# Altium schematic sheet Led_Matric_and_Driver.SchDoc(Led_Matric_and_Driver)
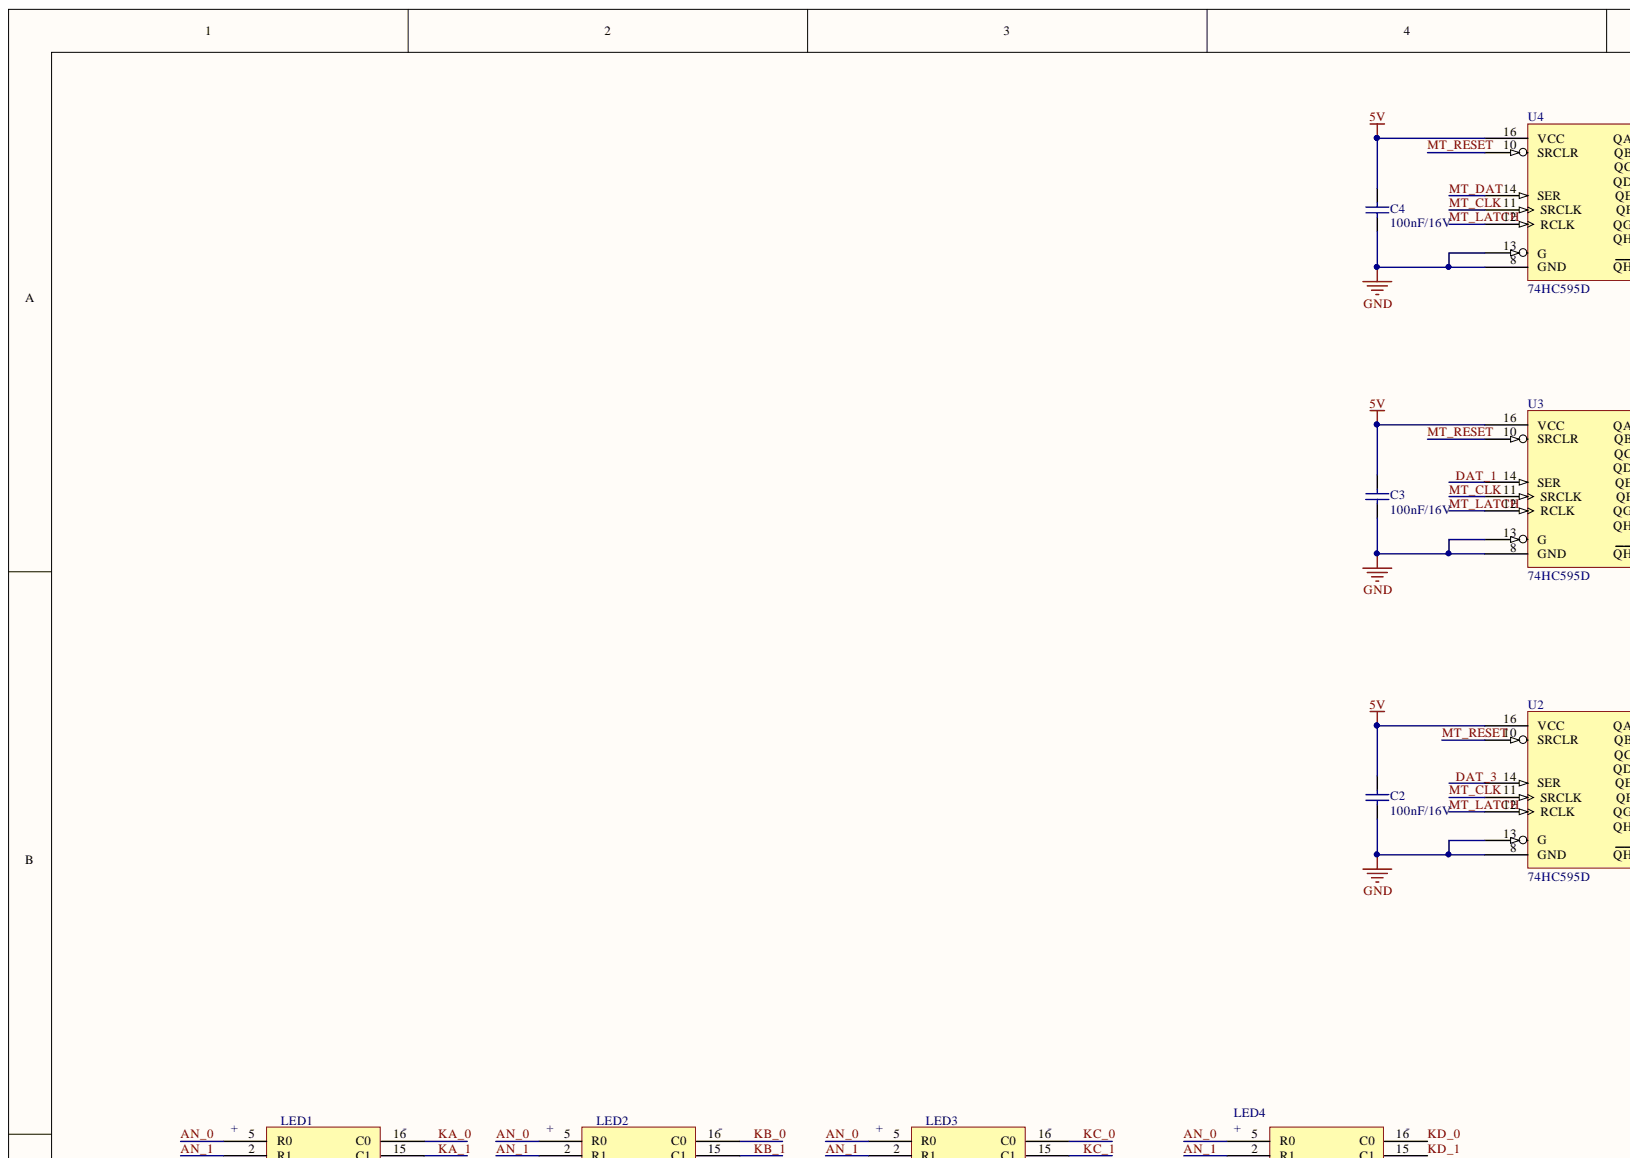
[diagram p0: Led_Matric_and_Driver.SchDoc, top left]
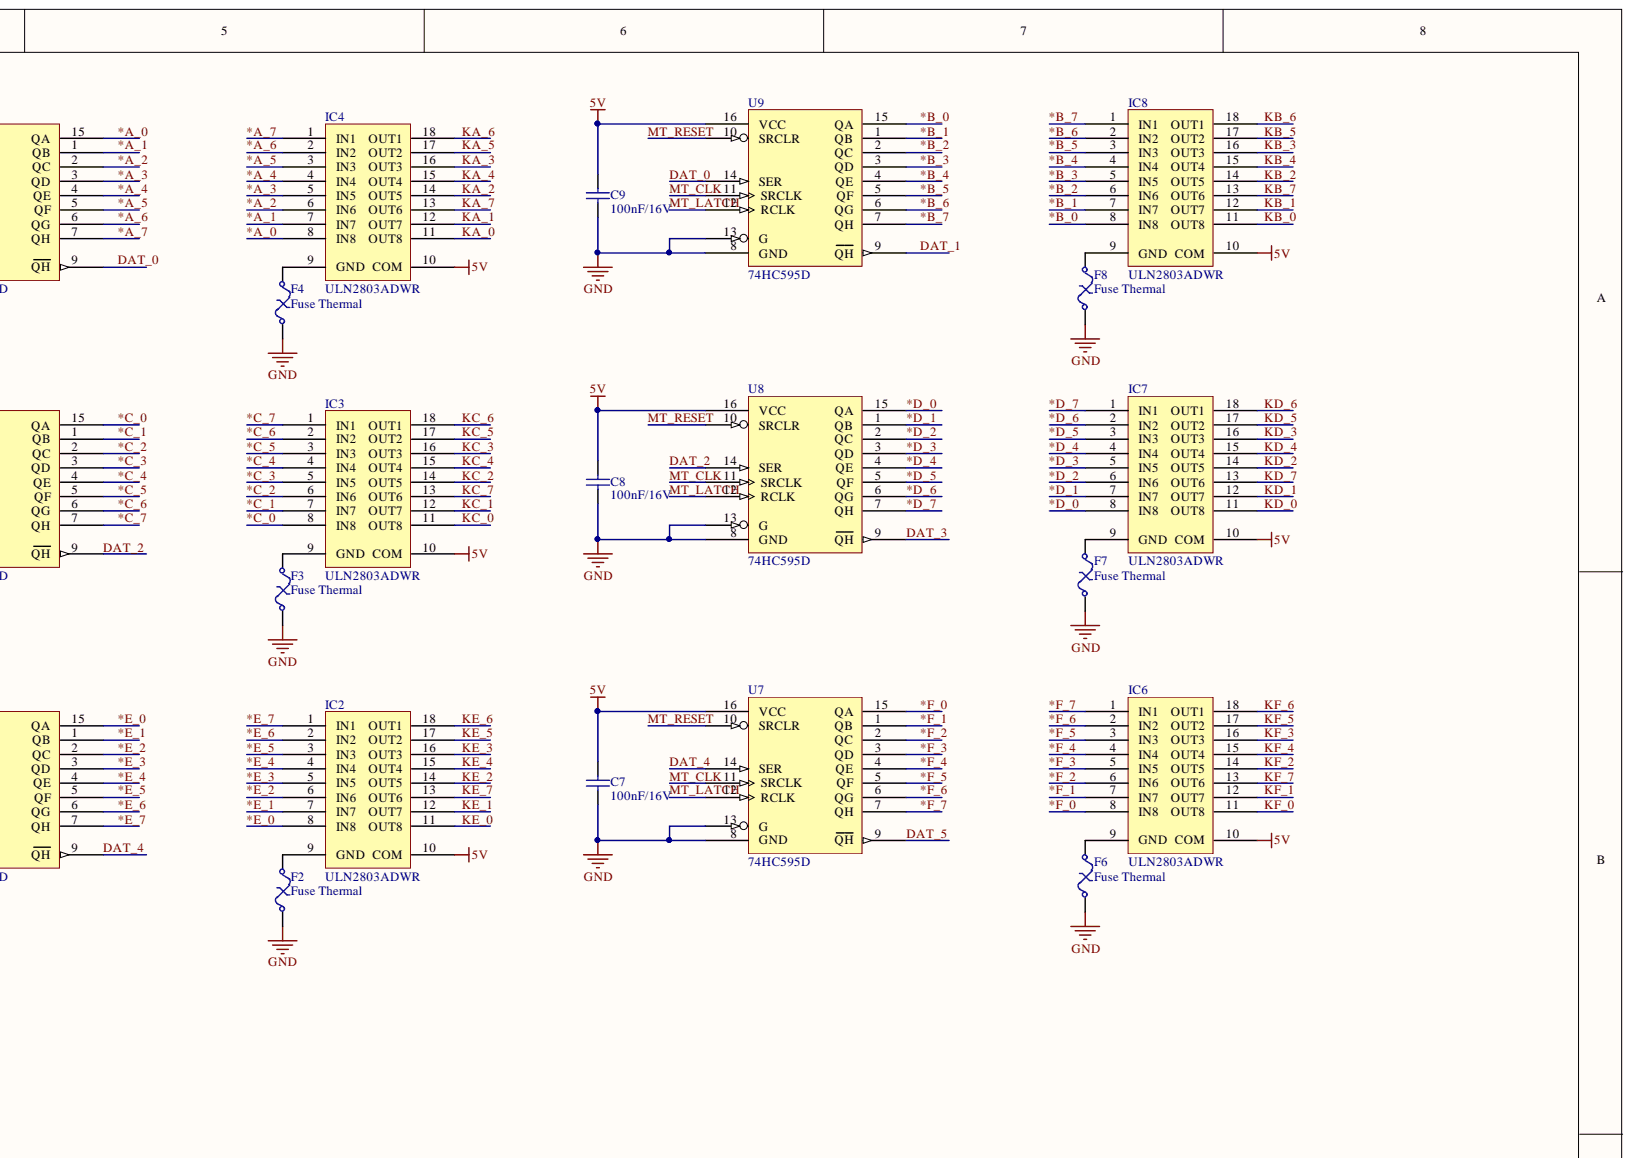
[diagram p1: Led_Matric_and_Driver.SchDoc, top right]
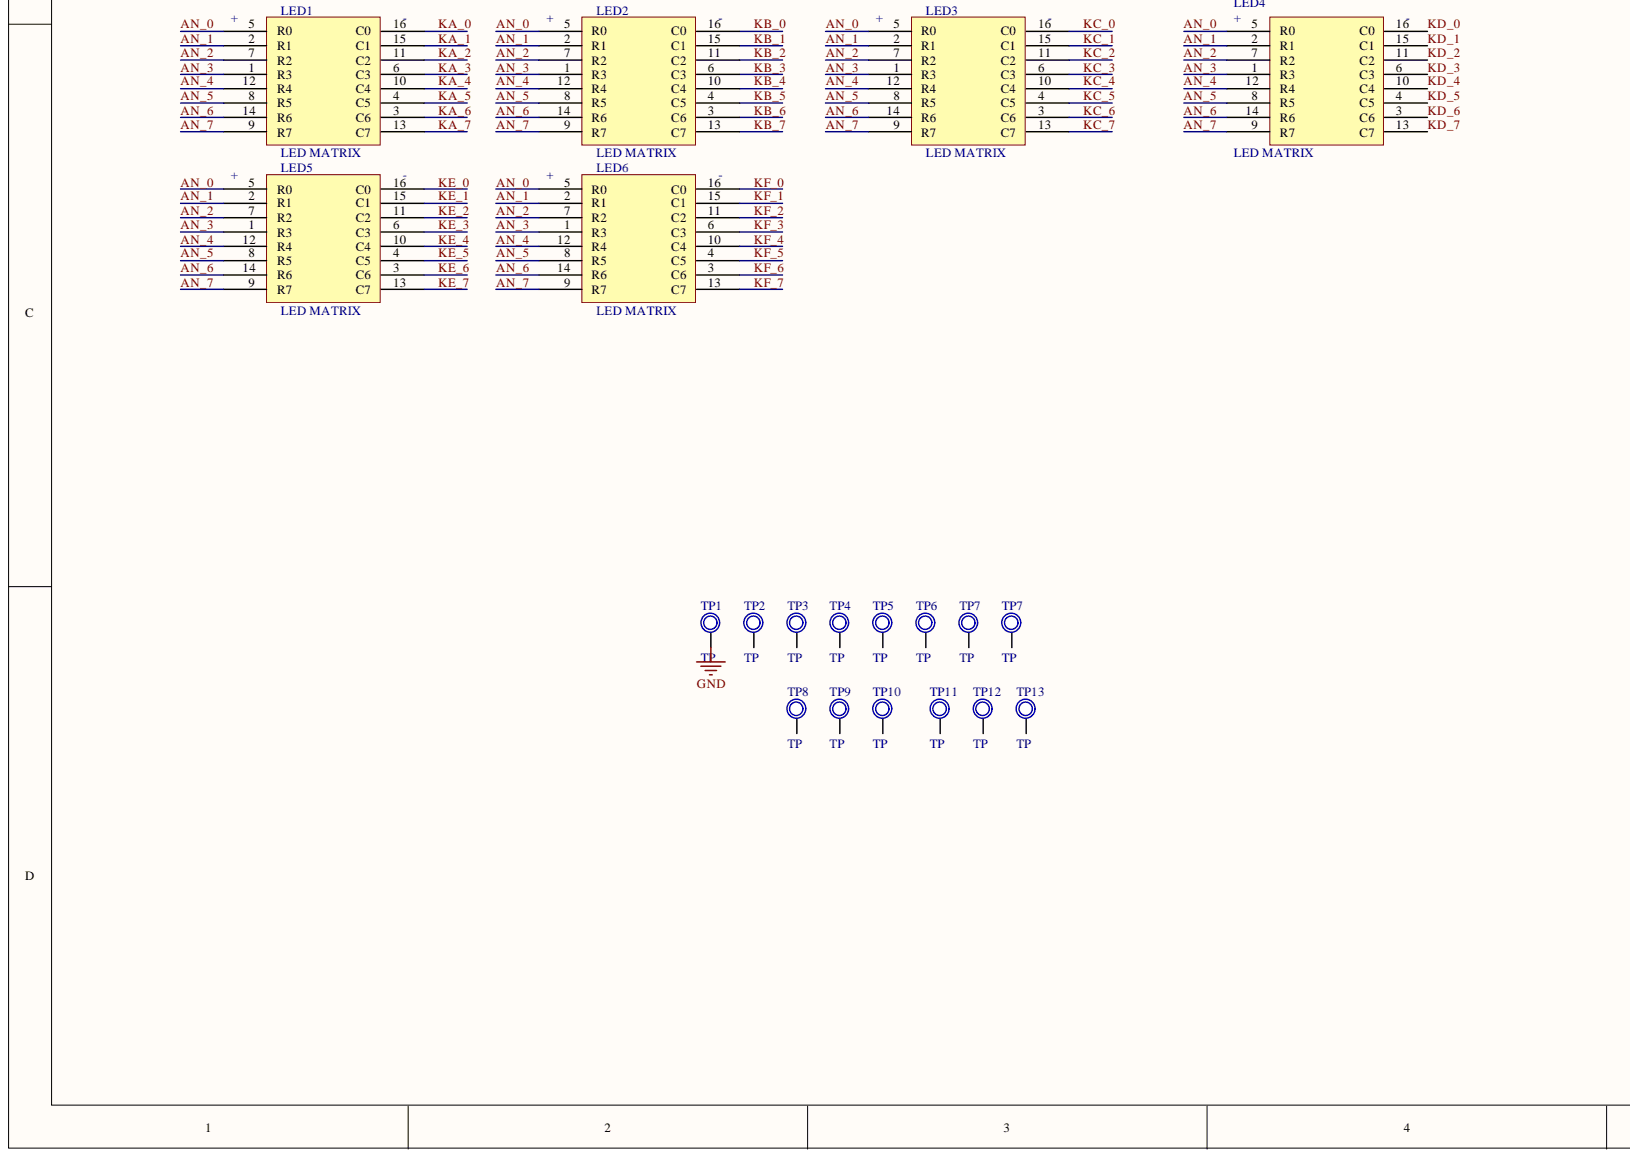
[diagram p2: Led_Matric_and_Driver.SchDoc, bottom left]
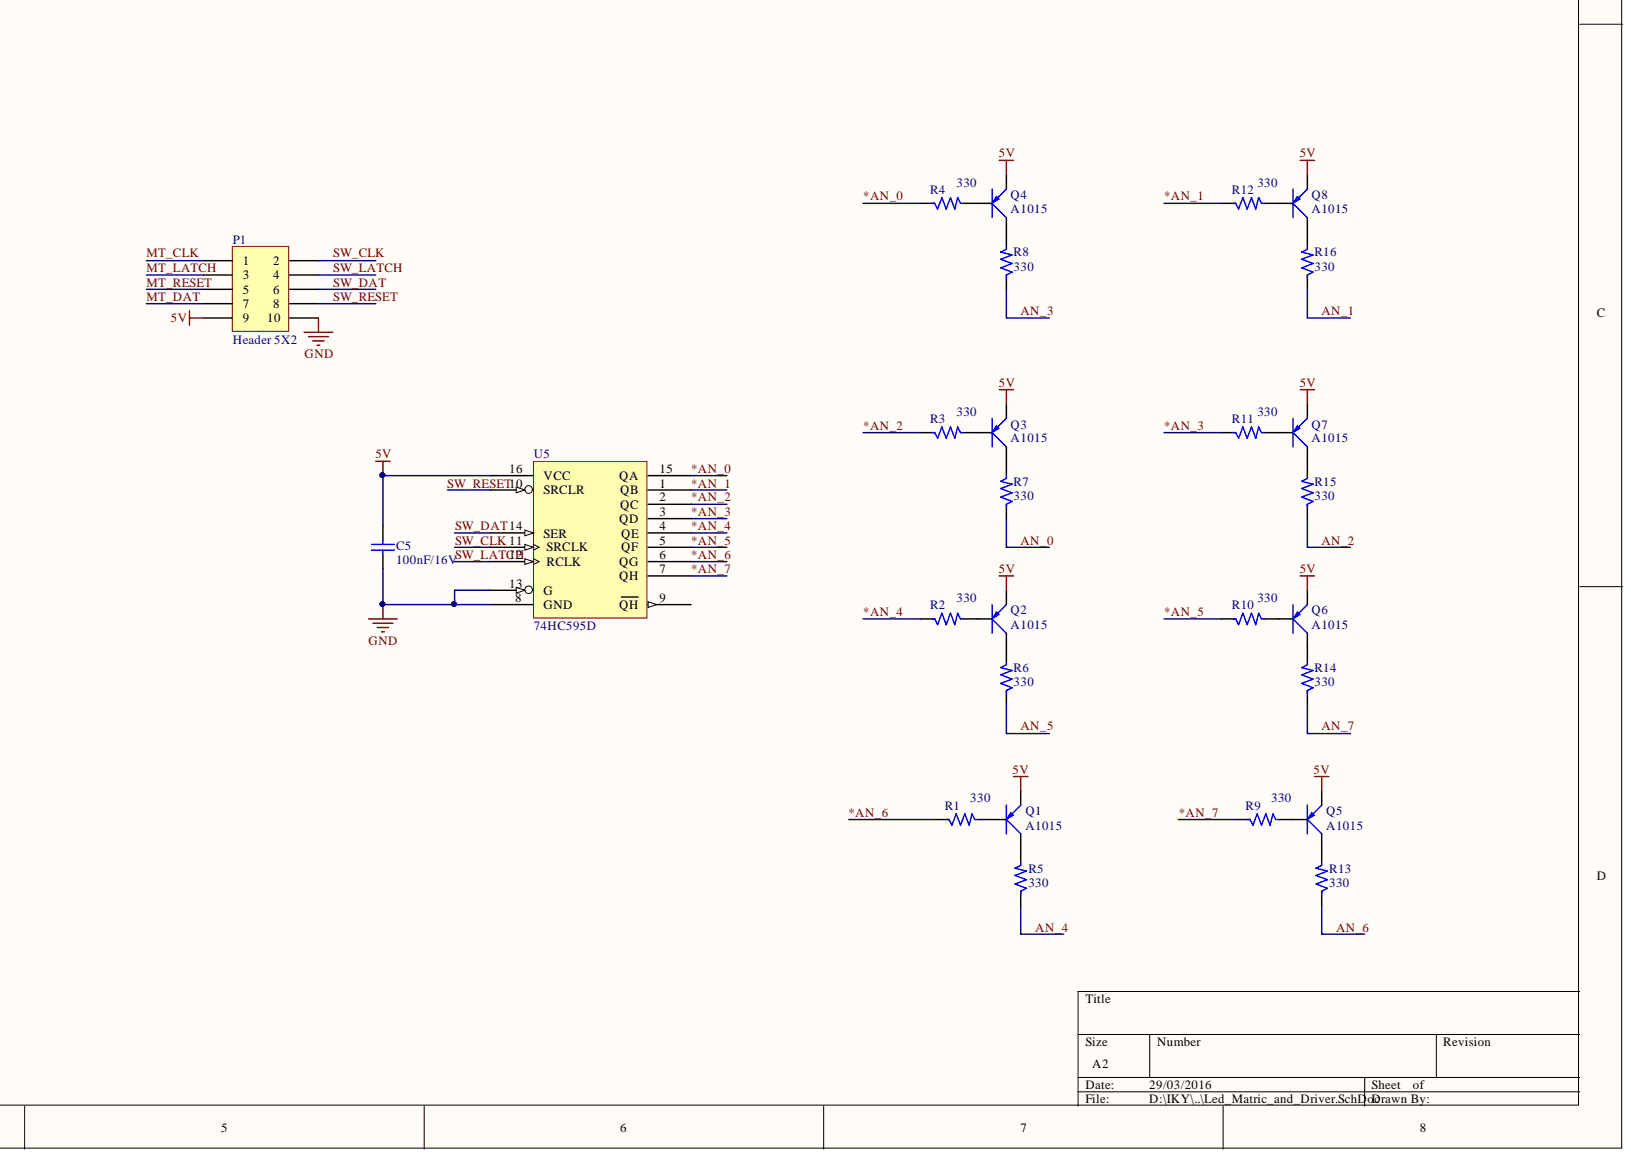
[diagram p3: Led_Matric_and_Driver.SchDoc, bottom right]

## Parts
C2  100nF/16V  [CAP SMD 0805.tdx]
C3  100nF/16V  [CAP SMD 0805.tdx]
C4  100nF/16V  [CAP SMD 0805.tdx]
C5  100nF/16V  [CAP SMD 0805.tdx]
C7  100nF/16V  [CAP SMD 0805.tdx]
C8  100nF/16V  [CAP SMD 0805.tdx]
C9  100nF/16V  [CAP SMD 0805.tdx]
F2  Fuse Thermal  [FUSE SMD 1812 FUSE.CVH]
F3  Fuse Thermal  [FUSE SMD 1812 FUSE.CVH]
F4  Fuse Thermal  [FUSE SMD 1812 FUSE.CVH]
F6  Fuse Thermal  [FUSE SMD 1812 FUSE.CVH]
F7  Fuse Thermal  [FUSE SMD 1812 FUSE.CVH]
F8  Fuse Thermal  [FUSE SMD 1812 FUSE.CVH]
IC2  ULN2803ADWR  [SOIC 18/W300.CVH]
IC3  ULN2803ADWR  [SOIC 18/W300.CVH]
IC4  ULN2803ADWR  [SOIC 18/W300.CVH]
IC6  ULN2803ADWR  [SOIC 18/W300.CVH]
IC7  ULN2803ADWR  [SOIC 18/W300.CVH]
IC8  ULN2803ADWR  [SOIC 18/W300.CVH]
LED1  LED MATRIX  [LED MATRIX.TDX]
LED2  LED MATRIX  [LED MATRIX.TDX]
LED3  LED MATRIX  [LED MATRIX.TDX]
LED4  LED MATRIX  [LED MATRIX.TDX]
LED5  LED MATRIX  [LED MATRIX.TDX]
LED6  LED MATRIX  [LED MATRIX.TDX]
P1  Header 5X2  [HEADER 5x2PIN FEMALE.CVH]
Q1  A1015  [SOT23-3.CVH]
Q2  A1015  [SOT23-3.CVH]
Q3  A1015  [SOT23-3.CVH]
Q4  A1015  [SOT23-3.CVH]
Q5  A1015  [SOT23-3.CVH]
Q6  A1015  [SOT23-3.CVH]
Q7  A1015  [SOT23-3.CVH]
Q8  A1015  [SOT23-3.CVH]
R1  330  [RESISTOR SMD 0805.TDX]
R2  330  [RESISTOR SMD 0805.TDX]
R3  330  [RESISTOR SMD 0805.TDX]
R4  330  [RESISTOR SMD 0805.TDX]
R5  330  [RESISTOR SMD 0805.TDX]
R6  330  [RESISTOR SMD 0805.TDX]
R7  330  [RESISTOR SMD 0805.TDX]
R8  330  [RESISTOR SMD 0805.TDX]
R9  330  [RESISTOR SMD 0805.TDX]
R10  330  [RESISTOR SMD 0805.TDX]
R11  330  [RESISTOR SMD 0805.TDX]
R12  330  [RESISTOR SMD 0805.TDX]
R13  330  [RESISTOR SMD 0805.TDX]
R14  330  [RESISTOR SMD 0805.TDX]
R15  330  [RESISTOR SMD 0805.TDX]
R16  330  [RESISTOR SMD 0805.TDX]
TP1  TP  [Holde_4mm.TDX]
TP2  TP  [Holde_4mm.TDX]
TP3  TP  [Holde_4mm.TDX]
TP4  TP  [Holde_4mm.TDX]
TP5  TP  [Holde_4mm.TDX]
TP6  TP  [Holde_4mm.TDX]
TP7  TP  [Holde_4mm.TDX]
TP7  TP  [Holde_4mm.TDX]
TP8  TP  [Holde_4mm.TDX]
TP9  TP  [Holde_4mm.TDX]
TP10  TP  [Holde_4mm.TDX]
TP11  TP  [Holde_4mm.TDX]
TP12  TP  [Holde_4mm.TDX]
TP13  TP  [Holde_4mm.TDX]
U2  74HC595D  [SOIC 16/W150.CVH]
U3  74HC595D  [SOIC 16/W150.CVH]
U4  74HC595D  [SOIC 16/W150.CVH]
U5  74HC595D  [SOIC 16/W150.CVH]
U7  74HC595D  [SOIC 16/W150.CVH]
U8  74HC595D  [SOIC 16/W150.CVH]
U9  74HC595D  [SOIC 16/W150.CVH]

## Nets
5V: C2.1 C3.1 C4.1 C5.1 C7.1 C8.1 C9.1 IC2.10(COM) IC3.10(COM) IC4.10(COM) IC6.10(COM) IC7.10(COM) IC8.10(COM) P1.9 Q1.2 Q2.2 Q3.2 Q4.2 Q5.2 Q6.2 Q7.2 Q8.2 U2.16(VCC) U3.16(VCC) U4.16(VCC) U5.16(VCC) U7.16(VCC) U8.16(VCC) U9.16(VCC)
GND: C2.2 C3.2 C4.2 C5.2 C7.2 C8.2 C9.2 F2.1 F3.1 F4.1 F6.1 F7.1 F8.1 P1.10 TP1.1 U2.8(GND) U2.13(G) U3.8(GND) U3.13(G) U4.8(GND) U4.13(G) U5.8(GND) U5.13(G) U7.8(GND) U7.13(G) U8.8(GND) U8.13(G) U9.8(GND) U9.13(G)
*A_0: IC4.8(IN8) U4.15(QA)
*A_1: IC4.7(IN7) U4.1(QB)
*A_2: IC4.6(IN6) U4.2(QC)
*A_3: IC4.5(IN5) U4.3(QD)
*A_4: IC4.4(IN4) U4.4(QE)
*A_5: IC4.3(IN3) U4.5(QF)
*A_6: IC4.2(IN2) U4.6(QG)
*A_7: IC4.1(IN1) U4.7(QH)
*AN_0: R4.1 U5.15(QA)
*AN_1: R12.1 U5.1(QB)
*AN_2: R3.1 U5.2(QC)
*AN_3: R11.1 U5.3(QD)
*AN_4: R2.1 U5.4(QE)
*AN_5: R10.1 U5.5(QF)
*AN_6: R1.1 U5.6(QG)
*AN_7: R9.1 U5.7(QH)
*B_0: IC8.8(IN8) U9.15(QA)
*B_1: IC8.7(IN7) U9.1(QB)
*B_2: IC8.6(IN6) U9.2(QC)
*B_3: IC8.5(IN5) U9.3(QD)
*B_4: IC8.4(IN4) U9.4(QE)
*B_5: IC8.3(IN3) U9.5(QF)
*B_6: IC8.2(IN2) U9.6(QG)
*B_7: IC8.1(IN1) U9.7(QH)
*C_0: IC3.8(IN8) U3.15(QA)
*C_1: IC3.7(IN7) U3.1(QB)
*C_2: IC3.6(IN6) U3.2(QC)
*C_3: IC3.5(IN5) U3.3(QD)
*C_4: IC3.4(IN4) U3.4(QE)
*C_5: IC3.3(IN3) U3.5(QF)
*C_6: IC3.2(IN2) U3.6(QG)
*C_7: IC3.1(IN1) U3.7(QH)
*D_0: IC7.8(IN8) U8.15(QA)
*D_1: IC7.7(IN7) U8.1(QB)
*D_2: IC7.6(IN6) U8.2(QC)
*D_3: IC7.5(IN5) U8.3(QD)
*D_4: IC7.4(IN4) U8.4(QE)
*D_5: IC7.3(IN3) U8.5(QF)
*D_6: IC7.2(IN2) U8.6(QG)
*D_7: IC7.1(IN1) U8.7(QH)
*E_0: IC2.8(IN8) U2.15(QA)
*E_1: IC2.7(IN7) U2.1(QB)
*E_2: IC2.6(IN6) U2.2(QC)
*E_3: IC2.5(IN5) U2.3(QD)
*E_4: IC2.4(IN4) U2.4(QE)
*E_5: IC2.3(IN3) U2.5(QF)
*E_6: IC2.2(IN2) U2.6(QG)
*E_7: IC2.1(IN1) U2.7(QH)
*F_0: IC6.8(IN8) U7.15(QA)
*F_1: IC6.7(IN7) U7.1(QB)
*F_2: IC6.6(IN6) U7.2(QC)
*F_3: IC6.5(IN5) U7.3(QD)
*F_4: IC6.4(IN4) U7.4(QE)
*F_5: IC6.3(IN3) U7.5(QF)
*F_6: IC6.2(IN2) U7.6(QG)
*F_7: IC6.1(IN1) U7.7(QH)
AN_0: LED1.5(R0) LED2.5(R0) LED3.5(R0) LED4.5(R0) LED5.5(R0) LED6.5(R0) R7.1
AN_1: LED1.2(R1) LED2.2(R1) LED3.2(R1) LED4.2(R1) LED5.2(R1) LED6.2(R1) R16.1
AN_2: LED1.7(R2) LED2.7(R2) LED3.7(R2) LED4.7(R2) LED5.7(R2) LED6.7(R2) R15.1
AN_3: LED1.1(R3) LED2.1(R3) LED3.1(R3) LED4.1(R3) LED5.1(R3) LED6.1(R3) R8.1
AN_4: LED1.12(R4) LED2.12(R4) LED3.12(R4) LED4.12(R4) LED5.12(R4) LED6.12(R4) R5.1
AN_5: LED1.8(R5) LED2.8(R5) LED3.8(R5) LED4.8(R5) LED5.8(R5) LED6.8(R5) R6.1
AN_6: LED1.14(R6) LED2.14(R6) LED3.14(R6) LED4.14(R6) LED5.14(R6) LED6.14(R6) R13.1
AN_7: LED1.9(R7) LED2.9(R7) LED3.9(R7) LED4.9(R7) LED5.9(R7) LED6.9(R7) R14.1
DAT_0: U4.9(QH) U9.14(SER)
DAT_1: U3.14(SER) U9.9(QH)
DAT_2: U3.9(QH) U8.14(SER)
DAT_3: U2.14(SER) U8.9(QH)
DAT_4: U2.9(QH) U7.14(SER)
DAT_5: U7.9(QH)
KA_0: IC4.11(OUT8) LED1.16(C0)
KA_1: IC4.12(OUT7) LED1.15(C1)
KA_2: IC4.14(OUT5) LED1.11(C2)
KA_3: IC4.16(OUT3) LED1.6(C3)
KA_4: IC4.15(OUT4) LED1.10(C4)
KA_5: IC4.17(OUT2) LED1.4(C5)
KA_6: IC4.18(OUT1) LED1.3(C6)
KA_7: IC4.13(OUT6) LED1.13(C7)
KB_0: IC8.11(OUT8) LED2.16(C0)
KB_1: IC8.12(OUT7) LED2.15(C1)
KB_2: IC8.14(OUT5) LED2.11(C2)
KB_3: IC8.16(OUT3) LED2.6(C3)
KB_4: IC8.15(OUT4) LED2.10(C4)
KB_5: IC8.17(OUT2) LED2.4(C5)
KB_6: IC8.18(OUT1) LED2.3(C6)
KB_7: IC8.13(OUT6) LED2.13(C7)
KC_0: IC3.11(OUT8) LED3.16(C0)
KC_1: IC3.12(OUT7) LED3.15(C1)
KC_2: IC3.14(OUT5) LED3.11(C2)
KC_3: IC3.16(OUT3) LED3.6(C3)
KC_4: IC3.15(OUT4) LED3.10(C4)
KC_5: IC3.17(OUT2) LED3.4(C5)
KC_6: IC3.18(OUT1) LED3.3(C6)
KC_7: IC3.13(OUT6) LED3.13(C7)
KD_0: IC7.11(OUT8) LED4.16(C0)
KD_1: IC7.12(OUT7) LED4.15(C1)
KD_2: IC7.14(OUT5) LED4.11(C2)
KD_3: IC7.16(OUT3) LED4.6(C3)
KD_4: IC7.15(OUT4) LED4.10(C4)
KD_5: IC7.17(OUT2) LED4.4(C5)
KD_6: IC7.18(OUT1) LED4.3(C6)
KD_7: IC7.13(OUT6) LED4.13(C7)
KE_0: IC2.11(OUT8) LED5.16(C0)
KE_1: IC2.12(OUT7) LED5.15(C1)
KE_2: IC2.14(OUT5) LED5.11(C2)
KE_3: IC2.16(OUT3) LED5.6(C3)
KE_4: IC2.15(OUT4) LED5.10(C4)
KE_5: IC2.17(OUT2) LED5.4(C5)
KE_6: IC2.18(OUT1) LED5.3(C6)
KE_7: IC2.13(OUT6) LED5.13(C7)
KF_0: IC6.11(OUT8) LED6.16(C0)
KF_1: IC6.12(OUT7) LED6.15(C1)
KF_2: IC6.14(OUT5) LED6.11(C2)
KF_3: IC6.16(OUT3) LED6.6(C3)
KF_4: IC6.15(OUT4) LED6.10(C4)
KF_5: IC6.17(OUT2) LED6.4(C5)
KF_6: IC6.18(OUT1) LED6.3(C6)
KF_7: IC6.13(OUT6) LED6.13(C7)
MT_CLK: P1.1 U2.11(SRCLK) U3.11(SRCLK) U4.11(SRCLK) U7.11(SRCLK) U8.11(SRCLK) U9.11(SRCLK)
MT_DAT: P1.7 U4.14(SER)
MT_LATCH: P1.3 U2.12(RCLK) U3.12(RCLK) U4.12(RCLK) U7.12(RCLK) U8.12(RCLK) U9.12(RCLK)
MT_RESET: P1.5 U2.10(SRCLR) U3.10(SRCLR) U4.10(SRCLR) U7.10(SRCLR) U8.10(SRCLR) U9.10(SRCLR)
SW_CLK: P1.2 U5.11(SRCLK)
SW_DAT: P1.6 U5.14(SER)
SW_LATCH: P1.4 U5.12(RCLK)
SW_RESET: P1.8 U5.10(SRCLR)
NetF2_2: F2.2 IC2.9(GND)
NetF3_2: F3.2 IC3.9(GND)
NetF4_2: F4.2 IC4.9(GND)
NetF6_2: F6.2 IC6.9(GND)
NetF7_2: F7.2 IC7.9(GND)
NetF8_2: F8.2 IC8.9(GND)
NetQ1_1: Q1.1 R1.2
NetQ1_3: Q1.3 R5.2
NetQ2_1: Q2.1 R2.2
NetQ2_3: Q2.3 R6.2
NetQ3_1: Q3.1 R3.2
NetQ3_3: Q3.3 R7.2
NetQ4_1: Q4.1 R4.2
NetQ4_3: Q4.3 R8.2
NetQ5_1: Q5.1 R9.2
NetQ5_3: Q5.3 R13.2
NetQ6_1: Q6.1 R10.2
NetQ6_3: Q6.3 R14.2
NetQ7_1: Q7.1 R11.2
NetQ7_3: Q7.3 R15.2
NetQ8_1: Q8.1 R12.2
NetQ8_3: Q8.3 R16.2

Not connected: TP2 1; TP3 1; TP4 1; TP5 1; TP6 1; TP7 1; TP8 1; TP9 1; TP10 1; TP11 1; TP12 1; TP13 1; U5 9(QH)

## Library data of the parts
C2-C5 C7-C9 = Capacitor NP: Ceramic capacitor; Manufacturer: Yageo; Value: 100nF/50V; Component Kind: Standard; Library Name: Altium Library.CVH.SchLib; Publisher: Cao Van Huong, vanhuongcao@gmail.com
F2-F4 F6-F8 = Fuse Thermal: Thermal Fuse; Component Kind: Standard; PackageReference: PIN-W2/E2.8; Library Name: Altium Library.CVH-TOANDINHXUAN-PC.SchLib; Publisher: Cao Van Huong, vanhuongcao@gmail.com
IC2-IC4 IC6-IC8 = ULN2803ADWR: TRANS 8NPN DARL 50V 0.5A 18SOL; Manufacturer: STMicroelectronics; Manufacturer P/N: ULN2004D; Component Kind: Standard; PackageReference: SOIC 16; Value: ULN2803ADWR; Library Name: Altium Library.CVH-TOANDINHXUAN-PC.SchLib; Publisher: Cao Van Huong, vanhuongcao@gmail.com
P1 = Header 5X2: Header, 5-Pin, Dual row; Component Kind: Standard; LatestRevisionDate: 17-Jul-2002; LatestRevisionNote: Re-released for DXP Platform.; Library Name: Altium Library.CVH-TOANDINHXUAN-PC.SchLib; Publisher: Altium Limited
Q1-Q8 = A1015: PNP Transistor, Ic=150mA; Component Kind: Standard; Library Name: Altium Library.CVH-TOANDINHXUAN-PC.SchLib; Publisher: Cao Van Huong, vanhuongcao@gmail.com
R1-R16 = Resistor: RESISTOR, THICK FILM, 2.7k, -1%/+1%, 0.063W, 50V, -55DEGC/+155DEGC, 0805, SMD; Manufacturer: Yageo; Value: 10K; Component Kind: Standard; PackageReference: R0805; Library Name: Altium Library.CVH-TOANDINHXUAN-PC.SchLib; Publisher: Cao Van Huong, vanhuongcao@gmail.com
TP1-TP7 TP7-TP13 = Test Point: Component Kind: Standard; Description: Test Point; Value: Test Point; Library Name: Altium Library.CVH-TOANDINHXUAN-PC.SchLib
U2-U5 U7-U9 = 74HC595D: 8-bit serial-in/serial or parallel-out  shift register with output latches; Component Kind: Standard; Library Name: Altium Library.CVH-TOANDINHXUAN-PC.SchLib; Publisher: Cao Van Huong, vanhuongcao@gmail.com
LED1-LED6 = LED MATRIX: Component Kind: Standard; Description: LED MATRIX; Library Name: Altium Library.CVH-TOANDINHXUAN-PC.SchLib; Publisher: Cao Van Huong, vanhuongcao@gmail.com
